# Altium schematic sheet GammaMRICAB_2 - Reception.SchDoc
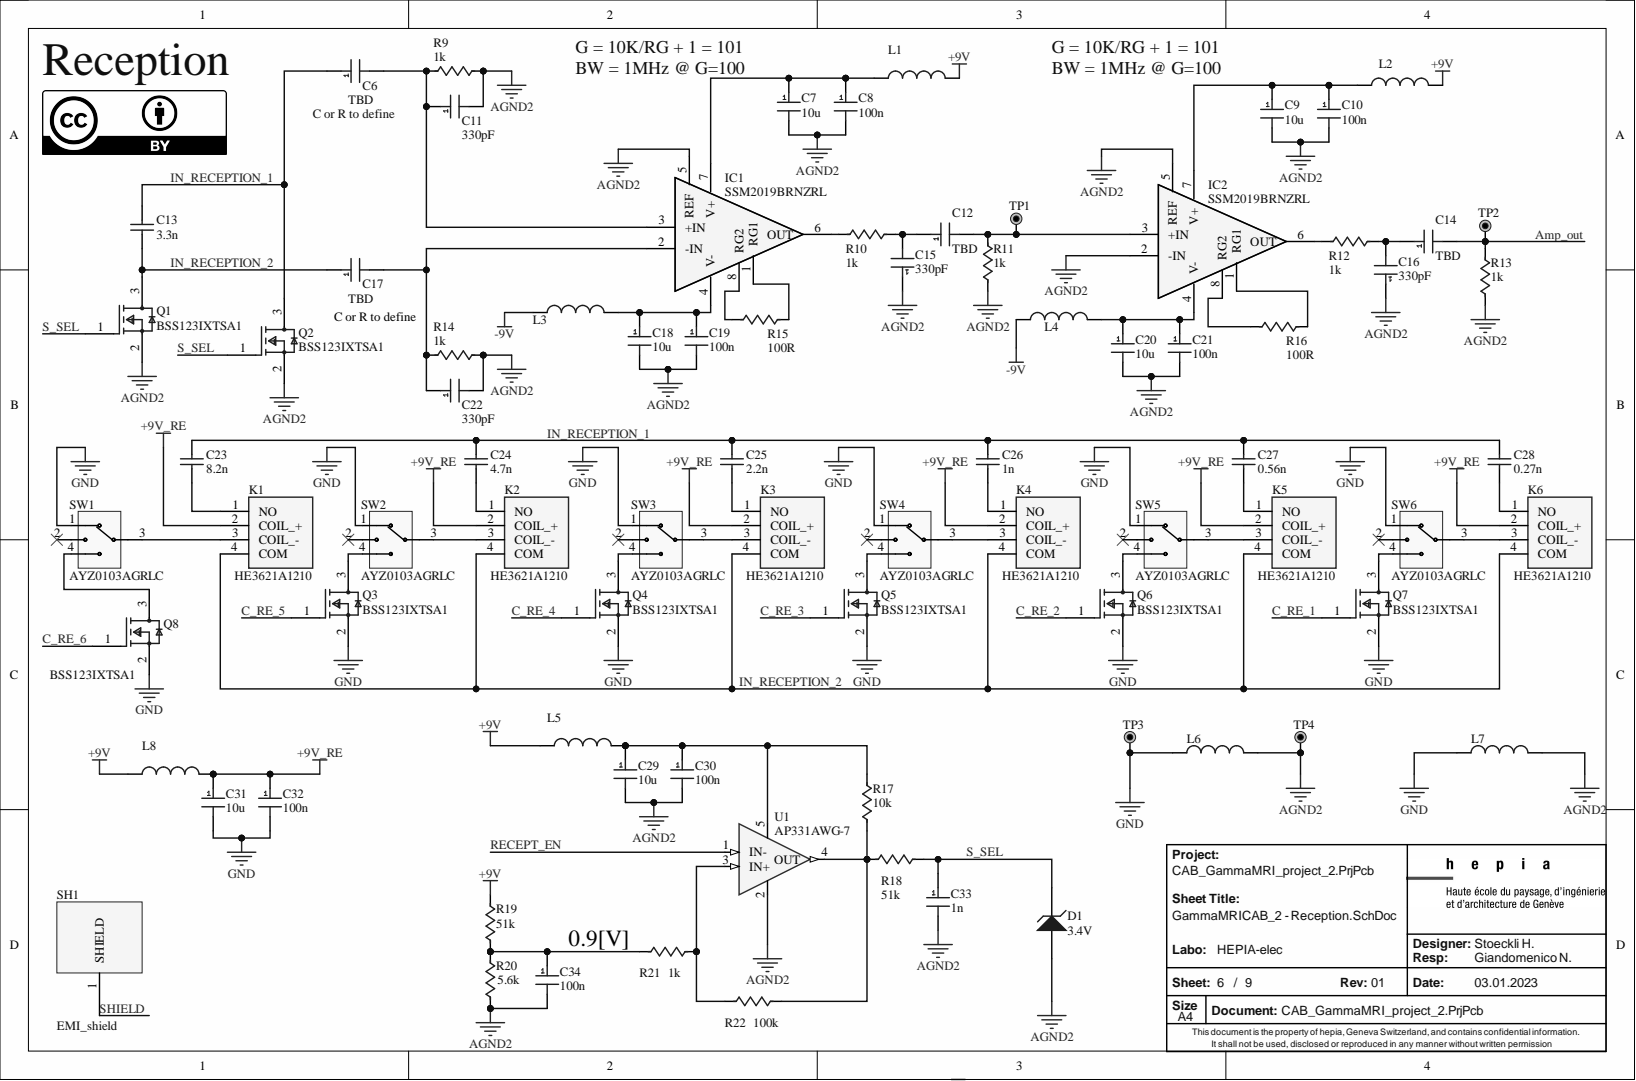
[diagram p0: GammaMRICAB_2 - Reception.SchDoc]

## Parts
C6  TBD  [1608[0603]]
C7  10u  [6-0805_M]
C8  100n  [1608[0603]]
C9  10u  [6-0805_M]
C10  100n  [1608[0603]]
C11  330pF  [1608[0603]]
C12  TBD  [1608[0603]]
C13  3.3n  [1608[0603]]
C14  TBD  [1608[0603]]
C15  330pF  [1608[0603]]
C16  330pF  [1608[0603]]
C17  TBD  [1608[0603]]
C18  10u  [6-0805_M]
C19  100n  [1608[0603]]
C20  10u  [6-0805_M]
C21  100n  [1608[0603]]
C22  330pF  [1608[0603]]
C23  8.2n  [1608[0603]]
C24  4.7n  [1608[0603]]
C25  2.2n  [1608[0603]]
C26  1n  [1608[0603]]
C27  0.56n  [1608[0603]]
C28  0.27n  [1608[0603]]
C29  10u  [6-0805_M]
C30  100n  [1608[0603]]
C31  10u  [6-0805_M]
C32  100n  [1608[0603]]
C33  1n  [1608[0603]]
C34  100n  [1608[0603]]
D1  3.4V  [SOD323]
IC1  SSM2019BRNZRL  [SOIC127P600X175-8N]
IC2  SSM2019BRNZRL  [SOIC127P600X175-8N]
K1  HE3621A1210  [HE3621A1210]
K2  HE3621A1210  [HE3621A1210]
K3  HE3621A1210  [HE3621A1210]
K4  HE3621A1210  [HE3621A1210]
K5  HE3621A1210  [HE3621A1210]
K6  HE3621A1210  [HE3621A1210]
L1  BKP0603HS121-T  [1608[0603]]  (value not drawn)
L2  BKP0603HS121-T  [1608[0603]]  (value not drawn)
L3  BKP0603HS121-T  [1608[0603]]  (value not drawn)
L4  BKP0603HS121-T  [1608[0603]]  (value not drawn)
L5  BKP0603HS121-T  [1608[0603]]  (value not drawn)
L6  BKP0603HS121-T  [1608[0603]]  (value not drawn)
L7  BKP0603HS121-T  [1608[0603]]  (value not drawn)
L8  BKP0603HS121-T  [1608[0603]]  (value not drawn)
Q1  BSS123IXTSA1  [FP-PG-SOT23-3-IPC_A]
Q2  BSS123IXTSA1  [FP-PG-SOT23-3-IPC_A]
Q3  BSS123IXTSA1  [FP-PG-SOT23-3-IPC_A]
Q4  BSS123IXTSA1  [FP-PG-SOT23-3-IPC_A]
Q5  BSS123IXTSA1  [FP-PG-SOT23-3-IPC_A]
Q6  BSS123IXTSA1  [FP-PG-SOT23-3-IPC_A]
Q7  BSS123IXTSA1  [FP-PG-SOT23-3-IPC_A]
Q8  BSS123IXTSA1  [FP-PG-SOT23-3-IPC_A]
R9  1k  [1608[0603]]
R10  1k  [1608[0603]]
R11  1k  [1608[0603]]
R12  1k  [1608[0603]]
R13  1k  [1608[0603]]
R14  1k  [1608[0603]]
R15  100R  [1608[0603]]
R16  100R  [1608[0603]]
R17  10k  [1608[0603]]
R18  51k  [1608[0603]]
R19  51k  [1608[0603]]
R20  5.6k  [1608[0603]]
R21  1k  [1608[0603]]
R22  100k  [1608[0603]]
SH1  EMI_shield  [EMI_shield]
SW1  AYZ0103AGRLC  [AYZ0103AGRLC]
SW2  AYZ0103AGRLC  [AYZ0103AGRLC]
SW3  AYZ0103AGRLC  [AYZ0103AGRLC]
SW4  AYZ0103AGRLC  [AYZ0103AGRLC]
SW5  AYZ0103AGRLC  [AYZ0103AGRLC]
SW6  AYZ0103AGRLC  [AYZ0103AGRLC]
TP1  TP_PAD_Hole  [TP_PAD_Hole]  (value not drawn)
TP2  TP_PAD_Hole  [TP_PAD_Hole]  (value not drawn)
TP3  TP_PAD_Hole  [TP_PAD_Hole]  (value not drawn)
TP4  TP_PAD_Hole  [TP_PAD_Hole]  (value not drawn)
U1  AP331AWG-7  [SOT95P285X145-5N]

## Nets
+9V: L1.2 L2.2 L5.1 L8.1 R19.1
+9V_RE: C31.1 C32.1 K1.2(COIL_+) K2.2(COIL_+) K3.2(COIL_+) K4.2(COIL_+) K5.2(COIL_+) K6.2(COIL_+) L8.2
-9V: L3.1 L4.1
AGND2: C7.2 C8.2 C9.2 C10.2 C11.2 C15.1 C16.1 C18.2 C19.2 C20.2 C21.2 C22.2 C29.2 C30.2 C33.2 C34.2 D1.1 IC1.5(REF) IC2.2(-IN) IC2.5(REF) L6.2 L7.2 Q1.2 Q2.2 R9.1 R11.2 R13.2 R14.1 R20.2 TP4.1 U1.2
GND: C31.2 C32.2 L6.1 L7.1 Q3.2 Q4.2 Q5.2 Q6.2 Q7.2 Q8.2 SW1.1 SW2.1 SW3.1 SW4.1 SW5.1 SW6.1 TP3.1
Amp_out: C14.2 R13.1 TP2.1
C_RE_1: Q7.1
C_RE_2: Q6.1
C_RE_3: Q5.1
C_RE_4: Q4.1
C_RE_5: Q3.1
C_RE_6: Q8.1
IN_RECEPTION_1: C6.1 C13.2 C23.2 C24.2 C25.2 C26.2 C27.2 C28.2 Q2.3
IN_RECEPTION_2: C13.1 C17.1 K1.4(COM) K2.4(COM) K3.4(COM) K4.4(COM) K5.4(COM) K6.4(COM) Q1.3
RECEPT_EN: U1.1(IN-)
S_SEL: C33.1 D1.2 Q1.1 Q2.1 R18.2
SHIELD: SH1.1
NetC6_2: C6.2 C11.1 IC1.3(+IN) R9.2
NetC7_1: C7.1 C8.1 IC1.7(V+) L1.1
NetC9_1: C9.1 C10.1 IC2.7(V+) L2.1
NetC12_1: C12.1 C15.2 R10.1
NetC12_2: C12.2 IC2.3(+IN) R11.1 TP1.1
NetC14_1: C14.1 C16.2 R12.1
NetC17_2: C17.2 C22.1 IC1.2(-IN) R14.2
NetC18_1: C18.1 C19.1 IC1.4(V-) L3.2
NetC20_1: C20.1 C21.1 IC2.4(V-) L4.2
NetC23_1: C23.1 K1.1(NO)
NetC24_1: C24.1 K2.1(NO)
NetC25_1: C25.1 K3.1(NO)
NetC26_1: C26.1 K4.1(NO)
NetC27_1: C27.1 K5.1(NO)
NetC28_1: C28.1 K6.1(NO)
NetC29_1: C29.1 C30.1 L5.2 R17.1 U1.5
NetC34_1: C34.1 R19.2 R20.1 R21.1
NetIC1_1: IC1.1(RG1) R15.1
NetIC1_6: IC1.6(OUT) R10.2
NetIC1_8: IC1.8(RG2) R15.2
NetIC2_1: IC2.1(RG1) R16.1
NetIC2_6: IC2.6(OUT) R12.2
NetIC2_8: IC2.8(RG2) R16.2
NetK1_3: K1.3(COIL_-) SW1.3
NetK2_3: K2.3(COIL_-) SW2.3
NetK3_3: K3.3(COIL_-) SW3.3
NetK4_3: K4.3(COIL_-) SW4.3
NetK5_3: K5.3(COIL_-) SW5.3
NetK6_3: K6.3(COIL_-) SW6.3
NetQ3_3: Q3.3 SW2.4
NetQ4_3: Q4.3 SW3.4
NetQ5_3: Q5.3 SW4.4
NetQ6_3: Q6.3 SW5.4
NetQ7_3: Q7.3 SW6.4
NetQ8_3: Q8.3 SW1.4
NetR17_2: R17.2 R18.1 R22.2 U1.4(OUT)
NetR21_2: R21.2 R22.1 U1.3(IN+)

Not connected: SW1 2; SW2 2; SW3 2; SW4 2; SW5 2; SW6 2

## Library data of the parts
C6 C11 C12 C14-C17 C22 = D_CAP-52: Boite Labo 0603: Ceramic Cap, 330pF, 10%, 50V, CAP_0603H0.8; Database Table Name: D_Capacitors$; Component Kind: Standard; Supplier1: Mouser; Library Name: Database_Altium_Hepia_Elec.DbLib
C7 C9 C18 C20 C29 C31 = D_CAP-69: Boite Labo 0603: Ceramic Cap, 10uF, 10%, 25V, CAP_0805H1.25; Database Table Name: D_Capacitors$; Component Kind: Standard; Supplier1: Mouser; Library Name: Database_Altium_Hepia_Elec.DbLib
C8 C10 C19 C21 C30 C32 C34 = D_CAP-52: Boite Labo 0603: Ceramic Cap, 100nF, 10%, 50V, CAP_0603H0.8; Database Table Name: D_Capacitors$; Component Kind: Standard; Supplier1: Mouser; Library Name: Database_Altium_Hepia_Elec.DbLib
C13 = Cap: Capacitor 3.3nF C0603 X6S 25V; Component Kind: Standard; Library Name: Miscellaneous Devices.IntLib; Signal Integrity: Cap; Simulation: CAP; Supplier1: Digikey
C23 = Cap: Capacitor 8.2nF C0603 X6S 25V; Component Kind: Standard; Library Name: Miscellaneous Devices.IntLib; Signal Integrity: Cap; Simulation: CAP; Supplier1: Digikey
C24 = Cap: Capacitor 4.7nF C0603 X6S 25V; Component Kind: Standard; Library Name: Miscellaneous Devices.IntLib; Signal Integrity: Cap; Simulation: CAP; Supplier1: Digikey
C25 = Cap: Capacitor 2.2nF C0603 X6S 25V; Component Kind: Standard; Library Name: Miscellaneous Devices.IntLib; Signal Integrity: Cap; Simulation: CAP; Supplier1: Digikey
C26 = Cap: Capacitor 1nF C0603 X6S 25V; Component Kind: Standard; Library Name: Miscellaneous Devices.IntLib; Signal Integrity: Cap; Simulation: CAP; Supplier1: Digikey
C27 = Cap: Capacitor 0.56nF C0603 X6S 25V; Component Kind: Standard; Library Name: Miscellaneous Devices.IntLib; Signal Integrity: Cap; Simulation: CAP; Supplier1: Digikey
C28 = Cap: Capacitor 0.27nF C0603 X6S 25V; Component Kind: Standard; Library Name: Miscellaneous Devices.IntLib; Signal Integrity: Cap; Simulation: CAP; Supplier1: Digikey
C33 = D_CAP-52: Boite Labo 0603: Ceramic Cap, 1nF, 10%, 50V, CAP_0603H0.8; Database Table Name: D_Capacitors$; Component Kind: Standard; Supplier1: Mouser; Library Name: Database_Altium_Hepia_Elec.DbLib
D1 = D Zener: Zener Diode; Component Kind: Standard; Library Name: Miscellaneous Devices.IntLib; Signal Integrity: D Zener; Simulation: ZENER; Supplier1: Digikey; Supplier1PN1: D3Z3V3BF-1
IC1 IC2 = SSM2019BRNZRL: Integrated Circuit; Component Kind: Standard; Supplier1: Digikey; Supplier1PN1: 505-SSM2019BRNZRLCT-ND; Library Name: CAB_SCH_LIB.SchLib
K1-K6 = HE3621A1210: Relay or Contactor; Component Kind: Standard; Supplier1: Digikey; Supplier1PN1: HE209-ND; Library Name: CAB_SCH_LIB.SchLib
L1-L8 = Inductor: FERRITE BEAD 120 OHM 0603(1608) 1LN; Component Kind: Standard; Library Name: Miscellaneous Devices.IntLib; Signal Integrity: Inductor; Simulation: INDUCTOR; Supplier1: Digikey; Supplier1PN1: 553-2387-1-ND
Q1-Q8 = BSS123IXTSA1: SMALL SIGNAL MOSFETS PG-SOT23-3; Component Kind: Standard; Supplier1: Digikey; Supplier1PN1: 448-BSS123IXTSA1DKR-ND; Library Name: CAB_SCH_LIB.SchLib
R9-R14 R17 R21 = D_RES-120: Thick Film Resistor, Boite Labo 0603, 1k 1%; Database Table Name: D_Resistors$; Component Kind: Standard; Supplier1: Digikey; Library Name: Database_Altium_Hepia_Elec.DbLib
R15 R16 = D_RES-120: Thick Film Resistor, Boite Labo 0603, 100R 1%; Database Table Name: D_Resistors$; Component Kind: Standard; Supplier1: Digikey; Library Name: Database_Altium_Hepia_Elec.DbLib
R18 R19 = D_RES-120: Thick Film Resistor, Boite Labo 0603, 51k 1%; Database Table Name: D_Resistors$; Component Kind: Standard; Supplier1: Digikey; Library Name: Database_Altium_Hepia_Elec.DbLib
R20 = D_RES-120: Thick Film Resistor, Boite Labo 0603, 5.6k 1%; Database Table Name: D_Resistors$; Component Kind: Standard; Supplier1: Digikey; Library Name: Database_Altium_Hepia_Elec.DbLib
R22 = D_RES-120: Thick Film Resistor, Boite Labo 0603, 100k 1%; Database Table Name: D_Resistors$; Component Kind: Standard; Supplier1: Digikey; Library Name: Database_Altium_Hepia_Elec.DbLib
SW1-SW6 = AYZ0103AGRLC: No Description Available; Component Kind: Standard; Supplier1: Digikey; Supplier1PN1: 401-2014-1-ND; Library Name: CAB_SCH_LIB.SchLib
SH1 = EMI_shield: Component Kind: Standard; Supplier1: Digikey; Supplier1PN1: 2333-MS423-10S-ND; Library Name: CAB_SCH_LIB.SchLib
TP1-TP4 = TP_PAD_Hole: Component Kind: Standard; Library Name: CAB_SCH_LIB.SchLib
U1 = AP331AWG-7: Component Kind: Standard; Supplier1: Digikey; Supplier1PN1: AP331AWGDICT-ND; Library Name: CAB_SCH_LIB.SchLib
